# Altium schematic sheet POWER.SchDoc
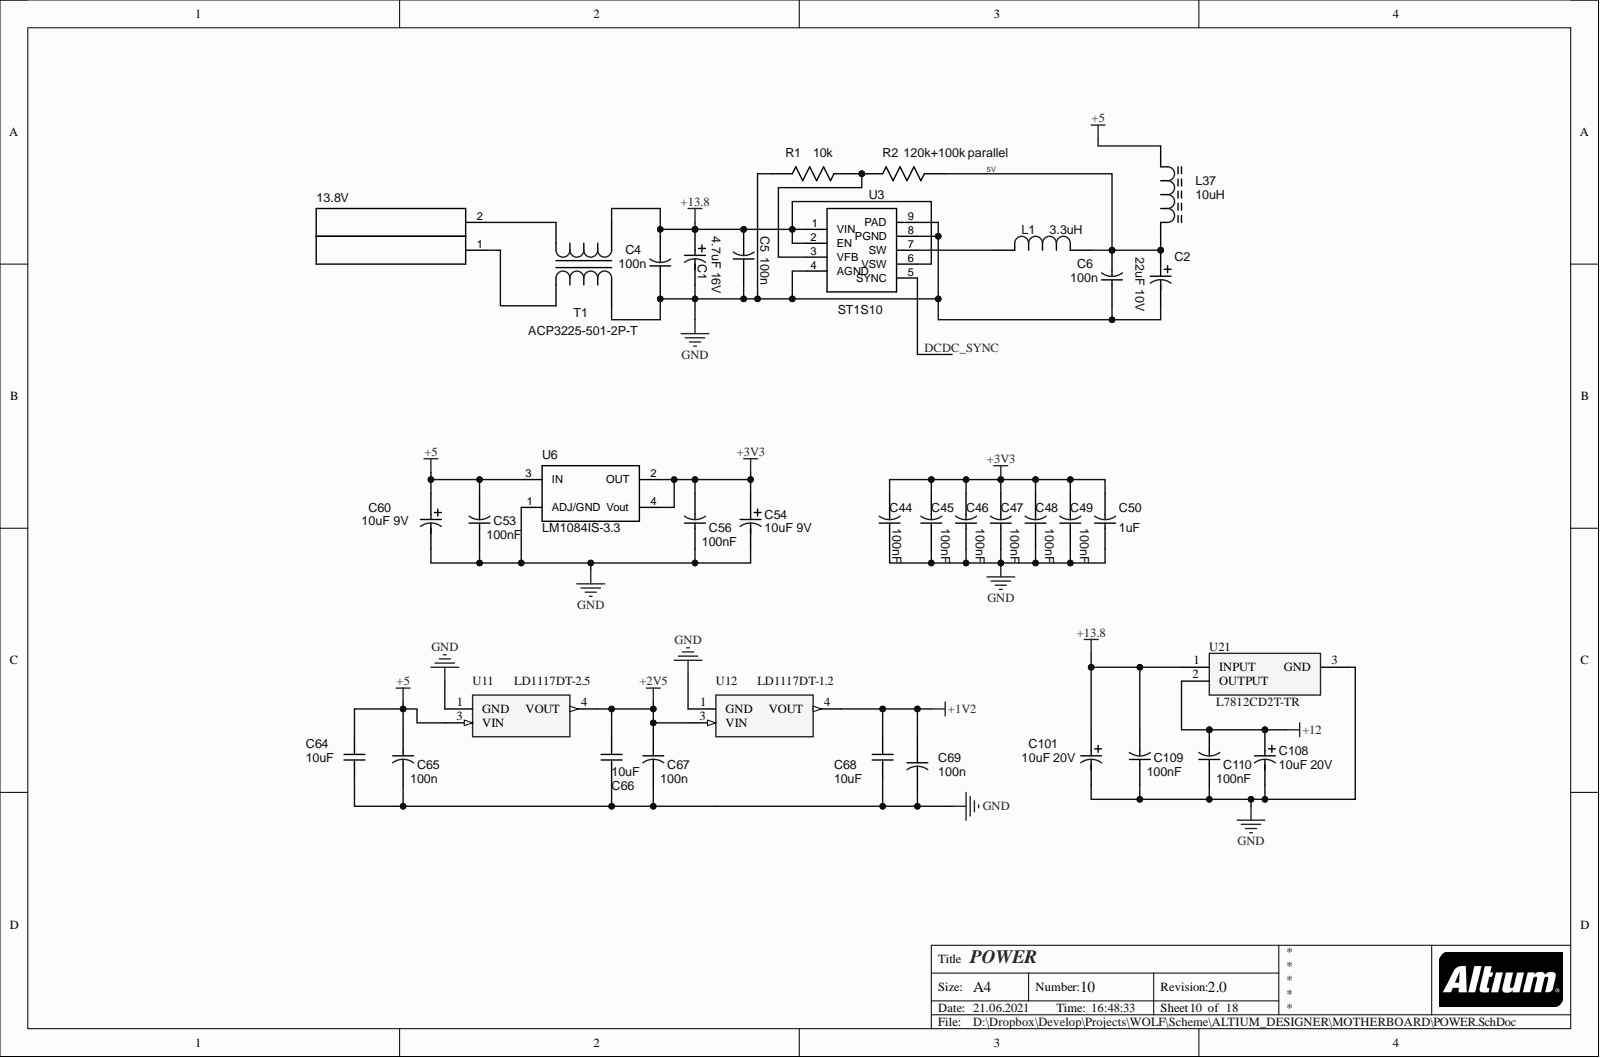
[diagram p0: POWER.SchDoc]

## Parts
13.8V  JX2  [JX2]  (value not drawn)
C1  4.7uF 16V  [CAPAE_6.6x6.6h5.4]
C2  22uF 10V  [CAPAE_4.3x4.3h5.4]
C4  100n  [CAP_0805]
C5  100n  [CAP_0805]
C6  100n  [CAP_0805]
C44  100nF  [CAP_0805]
C45  100nF  [CAP_0805]
C46  100nF  [CAP_0805]
C47  100nF  [CAP_0805]
C48  100nF  [CAP_0805]
C49  100nF  [CAP_0805]
C50  value 1uF  [CAP_0805]  (hidden comment: 10uF)
C53  100nF  [CAP_0805]
C54  10uF 9V  [CAPAE_4.3x4.3h5.4]
C56  100nF  [CAP_0805]
C60  10uF 9V  [CAPAE_4.3x4.3h5.4]
C64  10uF  [C0805]
C65  100n  [CAP_0805]
C66  10uF  [C0805]
C67  100n  [CAP_0805]
C68  10uF  [C0805]
C69  100n  [CAP_0805]
C101  10uF 20V  [CAPAE_4.3x4.3h5.4]
C108  10uF 20V  [CAPAE_4.3x4.3h5.4]
C109  100nF  [CAP_0805]
C110  100nF  [CAP_0805]
L1  3.3uH  [IND_1812]
L37  10uH  [IND_FERRITE_CORE]
R1  10k  [RES_0805]
R2  120k+100k  value 120k+100k parallel  [RES_0805]
T1  ACP3225-501-2P-T  [TRANSF_ICORE]
U3  ST1S10  [ST1S10]
U6  LM1084IS-3.3  [LM1085-3.3]
U11  LD1117DT-2.5  [TO228P991X238-3N]
U12  LD1117DT-1.2  [TO228P991X238-3N]
U21  L7812CD2T-TR  [L7812CD2TTR]

## Nets
+1V2: C68.1 C69.1 U12.4
+2V5: C66.1 C67.1 U11.4 U12.3
+3V3: C44.2 C45.2 C46.2 C47.2 C48.2 C49.2 C50.2 C54.1 C56.2 U6.2(OUT) U6.4(Vout)
+12: C108.1 C110.2 U21.2
+13.8: C1.1 C4.2 C5.2 C101.1 C109.2 T1.3 U3.1(VIN) U3.2(EN) U3.6(VSW) U21.1
GND: C1.2 C2.2 C4.1 C5.1 C6.1 C44.1 C45.1 C46.1 C47.1 C48.1 C49.1 C50.1 C53.1 C54.2 C56.1 C60.2 C64.2 C65.2 C66.2 C67.2 C68.2 C69.2 C101.2 C108.2 C109.1 C110.1 R1.1 T1.1 U3.4(AGND) U3.8(PGND) U3.9(PAD) U6.1(ADJ/GND) U11.1 U12.1 U21.3
5V: C2.1 C6.2 C53.2 C60.1 C64.1 C65.1 L1.2 L37.1 L37.2 R2.2 U6.3(IN) U11.3
DCDC_SYNC: U3.5(SYNC)
Net13.8V_1: 13.8V.1 T1.2
Net13.8V_2: 13.8V.2 T1.4
NetL1_1: L1.1 U3.7(SW)
NetR1_2: R1.2 R2.1 U3.3(VFB)

## Library data of the parts
C1 = CAPAE_6.6x6.6h5.4: Component Kind: Standard; Description: CAPAE_6.6x6.6h5.4; Value: 4.7uF 16V
C2 C54 C60 C101 C108 = CAPAE_4.3x4.3h5.4: Component Kind: Standard; Description: CAPAE_4.3x4.3h5.4
  C2: Value: 22uF 10V
  C54 C60: Value: 10uF 9V
  C101 C108: Value: 10uF 20V
C4-C6 C44-C50 C53 C56 C65 C67 C69 C109 C110 = CAP_0805: Component Kind: Standard; Description: CAP_0805
  C4-C6 C65 C67 C69: Value: 100n
  C44-C49 C53 C56 C109 C110: Value: 100nF
C64 C66 C68 = C0805: Component Kind: Standard; Description: C0805; Value: 10uF
L1 = IND_1812: Component Kind: Standard; Description: IND_1812; Value: 3.3uH
L37 = IND_FERRITE_CORE: Inductor; Component Kind: Standard; Library Name: MOTHERBOARD_1.SCHLIB; Value: 10uH
R1 R2 = RES_0805: Component Kind: Standard; Description: RES_0805
  R1: Value: 10k
T1 = TRANSF_ICORE: Transformer; Component Kind: Standard; Value: ACP3225-501-2P-T
U6 = LM1085-3.3: Component Kind: Standard; Description: LM1084IS-3.3
U11 = LM1117DT-ADJ: LD1117DT-2.5 DPAK; Manufacturer_Name: Texas Instruments; Component Kind: Standard; Datasheet Link: http://www.ti.com/lit/ds/symlink/lm1117-n.pdf; Height: 2.38mm; Manufacturer_Part_Number: LM1117DT-ADJ; Mouser Part Number: 926-LM1117DT-ADJ; Mouser Price/Stock: https://www.mouser.com/Search/Refine.aspx?Keyword=926-LM1117DT-ADJ
U12 = LM1117DT-ADJ: LD1117DT-1.2 DPAK; Manufacturer_Name: Texas Instruments; Component Kind: Standard; Datasheet Link: http://www.ti.com/lit/ds/symlink/lm1117-n.pdf; Height: 2.38mm; Manufacturer_Part_Number: LM1117DT-ADJ; Mouser Part Number: 926-LM1117DT-ADJ; Mouser Price/Stock: https://www.mouser.com/Search/Refine.aspx?Keyword=926-LM1117DT-ADJ
U21 = L7812CD2T-TR: Integrated Circuit; Manufacturer_Name: STMicroelectronics; Component Kind: Standard; Datasheet Link: https://www.st.com/content/ccc/resource/technical/document/datasheet/41/4f/b3/b0/12/d4/47/88/CD00000444.pdf/files/CD00000444.pdf/jcr:content/translations/en.CD00000444.pdf; Height: 4.5mm; Manufacturer_Part_Number: L7812CD2T-TR; Mouser Part Number: 511-L7812CD2T-TR; Mouser Price/Stock: https://www.mouser.co.uk/ProductDetail/STMicroelectronics/L7812CD2T-TR?qs=St21y7kBsOZRCvbPRwGnTg%3D%3D
13.8V = JX2: Component Kind: Standard; Description: JX2
U3 = ST1S10: Component Kind: Standard; Description: ST1S10; Value: ST1S10
